# Altium schematic sheet PWR.SchDoc
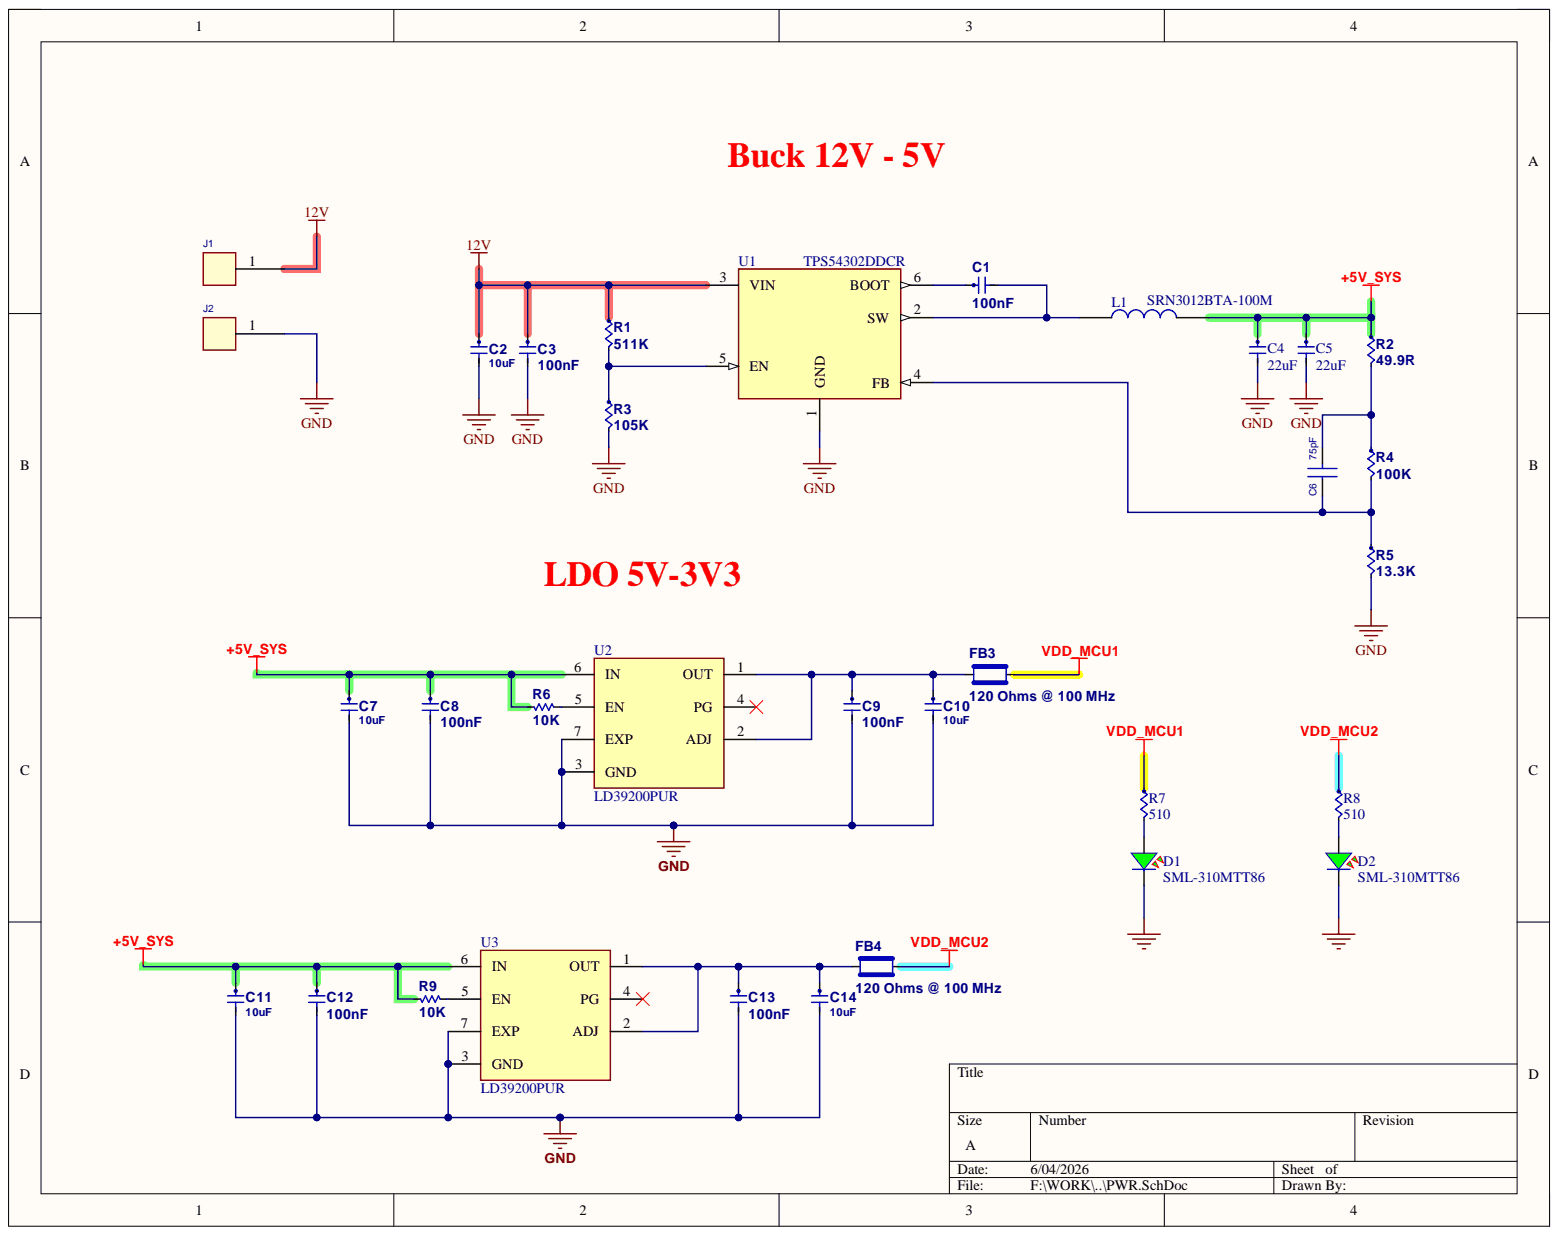
[diagram p0: PWR.SchDoc]

## Parts
C1  Capacitance: 100nF  [CAP0402]  (hidden comment: CC0402KRX5R9BB104)
C2  Capacitance: 10uF  [CAP0402]  (hidden comment: GRM188R6YA106MA73D)
C3  Capacitance: 100nF  [CAP0402]  (hidden comment: CC0402KRX5R9BB104)
C4  Capacitance: 22uF  [CAP0402]  (hidden comment: GRM158R61A226ME15D)
C5  Capacitance: 22uF  [CAP0402]  (hidden comment: GRM158R61A226ME15D)
C6  75pF  [CAP0402]
C7  Capacitance: 10uF  [CAP0603]  (hidden comment: GRM188R6YA106MA73D)
C8  Capacitance: 100nF  [CAP0402]  (hidden comment: CC0402KRX5R9BB104)
C9  Capacitance: 100nF  [CAP0402]  (hidden comment: CC0402KRX5R9BB104)
C10  Capacitance: 10uF  [CAP0603]  (hidden comment: GRM188R6YA106MA73D)
C11  Capacitance: 10uF  [CAP0603]  (hidden comment: GRM188R6YA106MA73D)
C12  Capacitance: 100nF  [CAP0402]  (hidden comment: CC0402KRX5R9BB104)
C13  Capacitance: 100nF  [CAP0402]  (hidden comment: CC0402KRX5R9BB104)
C14  Capacitance: 10uF  [CAP0603]  (hidden comment: GRM188R6YA106MA73D)
D1  SML-310MTT86  [LED0603 GREEN]
D2  SML-310MTT86  [LED0603 GREEN]
FB3  BLM15PX121SZ1D  [FERRIT0402]  (value not drawn)
FB4  BLM15PX121SZ1D  [FERRIT0402]  (value not drawn)
J1  CON1  [wire1_220s]  (value not drawn)
J2  CON1  [wire1_220s]  (value not drawn)
L1  SRN3012BTA-100M  [SRN3012BTA-100M]
R1  511K  [RES0402]
R2  49.9R  [RES0402]
R3  105K  [RES0402]
R4  100K  [RES0402]
R5  13.3K  [RES0402]
R6  value 10K  [RES0402]  (hidden comment: RES0402 10K)
R7  RT0402FRE07510RL  [RES0402]  (value not drawn)
R8  RT0402FRE07510RL  [RES0402]  (value not drawn)
R9  value 10K  [RES0402]  (hidden comment: RES0402 10K)
U1  TPS54302DDCR  [SOT95P280X110-6N - duplicate1]
U2  LD39200PUR  [LD39200PUR]
U3  LD39200PUR  [LD39200PUR]

## Nets
12V: C2.1 C3.1 J1.1 R1.1 U1.3(VIN)
+5V_SYS: C4.1 C5.1 C7.1 C8.1 C11.1 C12.1 L1.2 R2.1 R6.2 R9.2 U2.6(IN) U3.6(IN)
GND: C2.2 C3.2 C4.2 C5.2 C7.2 C8.2 C9.2 C10.2 C11.2 C12.2 C13.2 C14.2 D1.1 D2.1 J2.1 R3.2 R5.2 U1.1(GND) U2.3(GND) U2.7(EXP) U3.3(GND) U3.7(EXP)
VDD_MCU1: FB3.2 R7.1
VDD_MCU2: FB4.2 R8.1
NetC1_1: C1.1 U1.6(BOOT)
NetC1_2: C1.2 L1.1 U1.2(SW)
NetC6_1: C6.1 R4.2 R5.1 U1.4(FB)
NetC6_2: C6.2 R2.2 R4.1
NetC9_1: C9.1 C10.1 FB3.1 U2.1(OUT) U2.2(ADJ)
NetC13_1: C13.1 C14.1 FB4.1 U3.1(OUT) U3.2(ADJ)
NetD1_2: D1.2 R7.2
NetD2_2: D2.2 R8.2
NetR1_2: R1.2 R3.1 U1.5(EN)
NetR6_1: R6.1 U2.5(EN)
NetR9_1: R9.1 U3.5(EN)

Not connected: U2 4(PG); U3 4(PG)

## Library data of the parts
C1 C3 C8 C9 C12 C13 = CMP-00265-1: CAP CER 0.1UF 50V X5R 0402; Manufacturer: Yageo; Tolerance: 10%; Case/Package: 0402; Component Kind: Standard; Dielectric: X5R; Halogen Free: Halogen Free; Lead Free: Lead Free; Length: 0.039inch; Manufacturer 1: Yageo; Manufacturer Part Number: CC0402KRX5R9BB104; Manufacturer Part Number 1: CC0402KRX5R9BB104; Max Operating Temperature: 85°C; Min Operating Temperature: -55°C; Mount: Surface Mount; Packaging: Tape and Reel; Thickness: 0.022inch; Voltage Rating: 50V; Voltage Rating (DC): 50V; Width: 0.02inch; Library Name: rtr.IntLib
C2 C7 C10 C11 C14 = CMP-01031-1: CAP CER 10UF 35V X5R 0603; Manufacturer 1: Murata Electronics; Tolerance: 20%; Case Code (Imperial): 0603; Case Code (Metric): 1608; Case/Package: 0603; Component Kind: Standard; Composition: Ceramic; Depth: 0.8mm; Dielectric: X5R; Height: 0.8mm; Lead Free: Lead Free; Length: 1.6mm; Manufacturer Part Number 1: GRM188R6YA106MA73D; Max Operating Temperature: 85°C; Min Operating Temperature: -55°C; Mount: Surface Mount; Number of Pins: 2; Package Quantity: 1; Packaging: Tape and Reel; REACH SVHC: No SVHC; Termination: SMD/SMT; Thickness: 0.031inch; Voltage: 35V; Voltage Rating: 35V; Voltage Rating (DC): 35V; Weight: 0.000222oz; Width: 0.031inch; Library Name: rtr.IntLib
C4 C5 = CMP-00520-1: CAP CER 22UF 10V X5R 0402; Manufacturer 1: TDK Corporation; Tolerance: 10%; Case Code (Imperial): 0402; Case Code (Metric): 1005; Case/Package: 0402; Component Kind: Standard; Depth: 0.5mm; Dielectric: X5R; Length: 1mm; Library Name: CH961_CARRIER.IntLib; Manufacturer Part Number 1: GRM158R61A226ME15D; Max Operating Temperature: 85°C; Min Operating Temperature: -55°C; Packaging: Tape and Reel; Radiation Hardening: No; REACH SVHC: No SVHC; Termination: SMD/SMT; Voltage: 10V; Voltage Rating: 10V
C6 = CAP_Dup1_16: CAP CER 75PF 25V C0G/NP0 0402; Manufacturer: YAGEO; Manufacturer PN: CC0402JRNPO9BN750; PART_NUMBER: TMP-416; CLASS: DISCRETE; Dielectric Type: Capacitors; Temperature Coefficient: C0G, NP0; Tolerance: 5%; Component Kind: Standard; Library Name: motor MB1419.IntLib; Package Size: 0402 (1005 Metric); Rated Voltage: 25V
D1 D2 = CMP-01130-2: LED GREEN CLEAR 0603 SMD; Manufacturer 1: Rohm Semiconductor; Color: Green,Yellow; Dominant Wavelength: 570nm; Forward Current: 20mA; Forward Voltage: 2.2V; Lens Color: Colorless,Clear; Lens Style: Rectangular,Clear,Diffused,Colorless; Luminous Intensity: 16mcd; Number of LEDs: 1; Viewing Angle: 140°; Case Code (Imperial): 0603; Case Code (Metric): 1608; Case/Package: 0603; Component Kind: Standard; Height: 0.9mm; Illumination Color: Green; Lead Free: Lead Free; Length: 1.6mm; Lens Transparency: Clear; Manufacturer Part Number 1: SML-310MTT86; Max Operating Temperature: 85°C; Min Operating Temperature: -30°C; Mount: Surface Mount; Number of Pins: 2; Package Quantity: 3000; Packaging: Tape and Reel; Radiation Hardening: No; Test Current: 20mA; Wavelength: 570nm; Width: 0.8mm; Library Name: HeraJetson_Modified.IntLib
FB3 FB4 = BLM15PX121SZ1D: 120 Ohms @ 100 MHz 1 Power Line Ferrite Bead 0402 (1005 Metric) 2A 55mOhm; Component Kind: Standard; Current Rating (Max): 2A; DC Resistance (DCR) (Max): 55mOhm; Impedance @ Frequency: 120 Ohms @ 100 MHz; MP: BLM15PX121SZ1D; Library Name: RTR.SchLib
J1 J2 = CON1: SOLDER PADS; Component Kind: Standard; Library Name: motor MB1419.IntLib
L1 = SRN3012BTA-330M: 10uH; Manufacturer_Name: Bourns; Geometry.Height: 1.2mm; Component Kind: Standard; Datasheet Link: https://www.bourns.com/docs/Product-Datasheets/SRN3012BTA.pdf; Library Name: SRN3012BTA-330M.SchLib; Manufacturer_Part_Number: SRN3012BTA-100M; Mouser Part Number: SRN3012BTA-100M; Mouser Price/Stock: https://www.mouser.co.uk/ProductDetail/Bourns/SRN3012BTA-330M?qs=Imq1NPwxi74rPoSZ4PPaRw%3D%3D
R1 = CMP-00299-1_1: RES SMD 511K OHM 1% 1/16W 0402; Manufacturer: Yageo; Manufacturer Part Number: RC0402FR-07511KL; Max Power Dissipation: 63mW; Power Rating: 62.5mW; Temperature Coefficient: 100ppm/°C; Voltage Rating: 50V; Case Code (Imperial): 0402; Case Code (Metric): 1005; Case/Package: 0402; Component Kind: Standard; Composition: Thick Film; Depth: 0.5mm; Features: Moisture Resistant; Height: 0.016inch; Lead Free: Lead Free; Length: 1mm; Manufacturer 1: Yageo; Manufacturer Part Number 1: RC0402FR-07511KL; Max Operating Temperature: 155°C; Military Standard: Not; Min Operating Temperature: -55°C; Number of Terminations: 2; Package Quantity: 1; Packaging: Tape and Reel; Resistance: 511K; Termination: SMD/SMT; Tolerance: 1%; Voltage Rating (DC): 50V; Width: 0.02inch; Working Voltage: 50V; Library Name: rtr.IntLib
R2 = CMP-00299-1_1: RES SMD 49.9R OHM 1% 1/16W 0402; Manufacturer: Yageo; Manufacturer Part Number: RC0402FR-0749R9L; Max Power Dissipation: 63mW; Power Rating: 62.5mW; Temperature Coefficient: 100ppm/°C; Voltage Rating: 50V; Case Code (Imperial): 0402; Case Code (Metric): 1005; Case/Package: 0402; Component Kind: Standard; Composition: Thick Film; Depth: 0.5mm; Features: Moisture Resistant; Height: 0.016inch; Lead Free: Lead Free; Length: 1mm; Manufacturer 1: Yageo; Manufacturer Part Number 1: RC0402FR-0749R9L; Max Operating Temperature: 155°C; Military Standard: Not; Min Operating Temperature: -55°C; Number of Terminations: 2; Package Quantity: 1; Packaging: Tape and Reel; Resistance: 49.9R; Termination: SMD/SMT; Tolerance: 1%; Voltage Rating (DC): 50V; Width: 0.02inch; Working Voltage: 50V; Library Name: rtr.IntLib
R3 = CMP-00299-1_1: RES SMD 105K OHM 1% 1/16W 0402; Manufacturer: Yageo; Manufacturer Part Number: RC0402FR-07105KL; Max Power Dissipation: 63mW; Power Rating: 62.5mW; Temperature Coefficient: 100ppm/°C; Voltage Rating: 50V; Case Code (Imperial): 0402; Case Code (Metric): 1005; Case/Package: 0402; Component Kind: Standard; Composition: Thick Film; Depth: 0.5mm; Features: Moisture Resistant; Height: 0.016inch; Lead Free: Lead Free; Length: 1mm; Manufacturer 1: Yageo; Manufacturer Part Number 1: RC0402FR-07105KL; Max Operating Temperature: 155°C; Military Standard: Not; Min Operating Temperature: -55°C; Number of Terminations: 2; Package Quantity: 1; Packaging: Tape and Reel; Resistance: 105K; Termination: SMD/SMT; Tolerance: 1%; Voltage Rating (DC): 50V; Width: 0.02inch; Working Voltage: 50V; Library Name: rtr.IntLib
R4 = CMP-00299-1_1: RES SMD 100K OHM 1% 1/16W 0402; Manufacturer: Yageo; Manufacturer Part Number: RC0402FR-07100KL; Max Power Dissipation: 63mW; Power Rating: 62.5mW; Temperature Coefficient: 100ppm/°C; Voltage Rating: 50V; Case Code (Imperial): 0402; Case Code (Metric): 1005; Case/Package: 0402; Component Kind: Standard; Composition: Thick Film; Depth: 0.5mm; Features: Moisture Resistant; Height: 0.016inch; Lead Free: Lead Free; Length: 1mm; Manufacturer 1: Yageo; Manufacturer Part Number 1: RC0402FR-07100KL; Max Operating Temperature: 155°C; Military Standard: Not; Min Operating Temperature: -55°C; Number of Terminations: 2; Package Quantity: 1; Packaging: Tape and Reel; Resistance: 100K; Termination: SMD/SMT; Tolerance: 1%; Voltage Rating (DC): 50V; Width: 0.02inch; Working Voltage: 50V; Library Name: rtr.IntLib
R5 = CMP-00299-1_1: RES SMD 13.3K OHM 1% 1/16W 0402; Manufacturer: Yageo; Manufacturer Part Number: RC0402FR-0713K3L; Max Power Dissipation: 63mW; Power Rating: 62.5mW; Temperature Coefficient: 100ppm/°C; Voltage Rating: 50V; Case Code (Imperial): 0402; Case Code (Metric): 1005; Case/Package: 0402; Component Kind: Standard; Composition: Thick Film; Depth: 0.5mm; Features: Moisture Resistant; Height: 0.016inch; Lead Free: Lead Free; Length: 1mm; Manufacturer 1: Yageo; Manufacturer Part Number 1: RC0402FR-0713K3L; Max Operating Temperature: 155°C; Military Standard: Not; Min Operating Temperature: -55°C; Number of Terminations: 2; Package Quantity: 1; Packaging: Tape and Reel; Resistance: 13.3K; Termination: SMD/SMT; Tolerance: 1%; Voltage Rating (DC): 50V; Width: 0.02inch; Working Voltage: 50V; Library Name: rtr.IntLib
R6 R9 = RES0402 10K: RES 10K 0402; MP: RC0402FR-0710KL; Component Kind: Standard; Library Name: RTR.SchLib
R7 R8 = RC0402FR-0722KL: RES SMD 510 OHM 1% 1/16W 0402; Manufacturer: Yageo; Max Power Dissipation: 63mW; Power Rating: 62.5mW; Resistance: 510; Temperature Coefficient: 100ppm/°C; Voltage Rating: 50V; Case Code (Imperial): 0402; Case Code (Metric): 1005; Case/Package: 0402; Category: Chip SMD Resistors; Component Kind: Standard; Construction: Rectangular; Depth: 0.5mm; Features: Moisture Resistant; Height: 0.016inch; Lead Free: Lead Free; Length: 0.039inch; Library Name: CH961_CARRIER.IntLib; Manufacturer Part Number: RT0402FRE07510RL; Max Operating Temperature: 155°C; Military Standard: Not; Min Operating Temperature: -55°C; Number of Terminations: 2; Package Quantity: 1; Packaging: Tape and Reel; Pricing 1: 10000=0.00100, 50000=0.00090, 300000=0.00080, 1000000=0.00080, 3000000=0.00070 (USD); Reach SVHC Compliant: Yes; Resistance Tolerance: 1%; Resistor Type: Thick Film; RoHS: Yes; RoHS Compliant: Yes; Series: RC; Stock 1: 1010000; Supplier 1: Future Electronics; Termination: SMD/SMT; Tolerance: 1%; Width: 0.02inch; Working Voltage: 50V
U1 = TPS54302DDCR: 4.5-V to 28-V Input, 3-A Output, EMI Friendly Synchronous Step-Down Converter; MF: Texas Instruments; Availability: In Stock; Check_prices: https://www.snapeda.com/parts/TPS54302DDCR/Texas+Instruments/view-part/?ref=eda; Component Kind: Standard; Library Name: TPS54302DDCR.IntLib; MP: TPS54302DDCR; Package: SOT-23-THN-6 Texas Instruments; Price: None; Purchase-URL: https://pricing.snapeda.com/search?q=TPS54302DDCR&ref=eda; SnapEDA_Link: https://www.snapeda.com/parts/TPS54302DDCR/Texas+Instruments/view-part/?ref=snap
U2 U3 = LD39200PUR: LDO IC 1 Output 2A 6-DFN _3x3_; MF: STMicroelectronics; Availability: Unavailable; Component Kind: Standard; MP: LD39200PUR; Package: DFN-6 STMicroelectronics; Price: None; SnapEDA_Link: https://www.snapeda.com/parts/LD39200PUR/STMicroelectronics/view-part/882017/?ref=snap; Library Name: RTR.SchLib
